# Altium schematic sheet Power.SchDoc
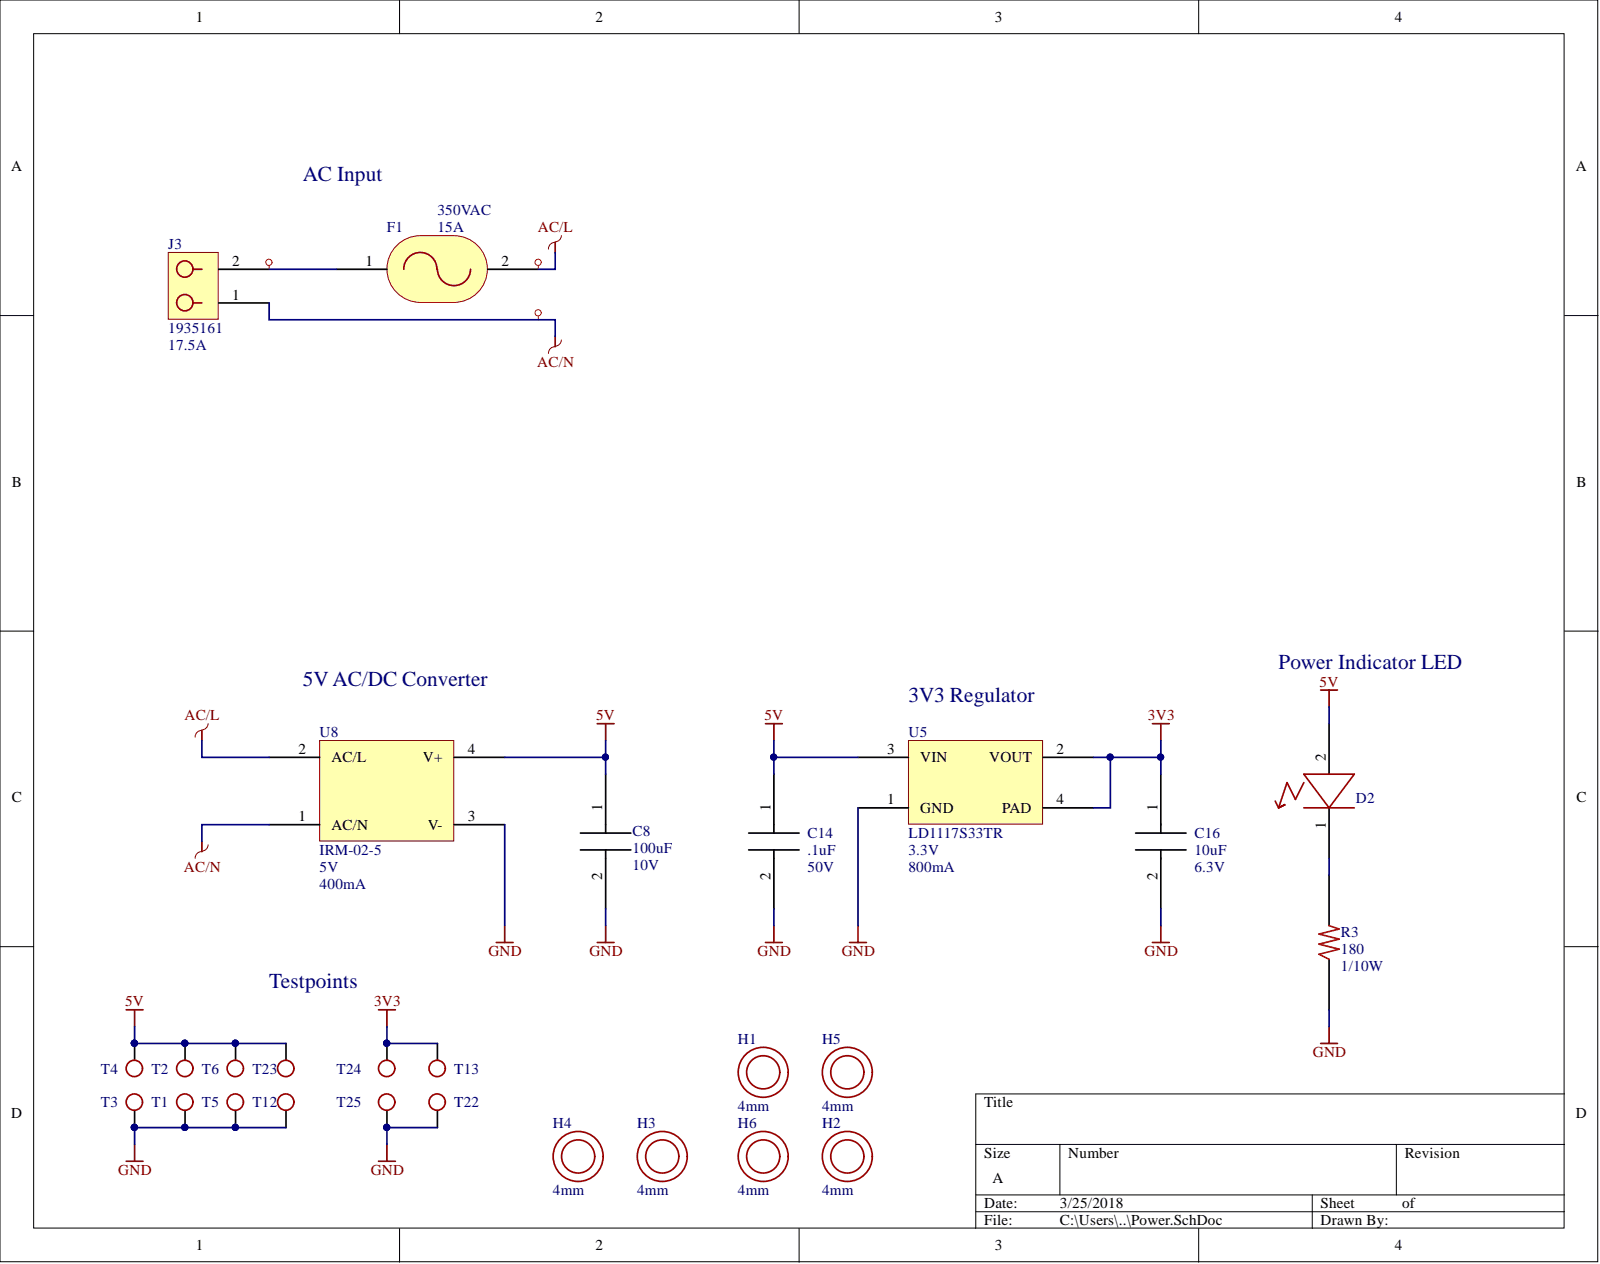
[diagram p0: Power.SchDoc]

## Parts
C8  value 100uF  Rating: 10V  [1210]  (hidden comment: C_CAP_1210)
C14  value .1uF  Rating: 50V  [0603]  (hidden comment: C_CAP_0603)
C16  value 10uF  Rating: 6.3V  [0603]  (hidden comment: C_CAP_0603)
D2  D_LED_LED5MM  [LED5MM]  (value not drawn)
F1  value 15A  Rating: 350VAC  [2410]  (hidden comment: F_FUSE_2410)
H1  value 4mm  [MOUNTHOLE4MM]  (hidden comment: H_MOUNTHOLE_MOUNTHOLE4MM)
H2  value 4mm  [MOUNTHOLE4MM]  (hidden comment: H_MOUNTHOLE_MOUNTHOLE4MM)
H3  value 4mm  [MOUNTHOLE4MM]  (hidden comment: H_MOUNTHOLE_MOUNTHOLE4MM)
H4  value 4mm  [MOUNTHOLE4MM]  (hidden comment: H_MOUNTHOLE_MOUNTHOLE4MM)
H5  value 4mm  [MOUNTHOLE4MM]  (hidden comment: H_MOUNTHOLE_MOUNTHOLE4MM)
H6  value 4mm  [MOUNTHOLE4MM]  (hidden comment: H_MOUNTHOLE_MOUNTHOLE4MM)
J3  Rating: 17.5A  [1935161]  (hidden comment: J_2POSTERM_1935161)
R3  value 180  Rating: 1/10W  [0603]  (hidden comment: R_RES_0603)
T1  TESTPOINT  [TESTPOINT1MM]  (value not drawn)
T2  TESTPOINT  [TESTPOINT1MM]  (value not drawn)
T3  TESTPOINT  [TESTPOINT1MM]  (value not drawn)
T4  TESTPOINT  [TESTPOINT1MM]  (value not drawn)
T5  TESTPOINT  [TESTPOINT1MM]  (value not drawn)
T6  TESTPOINT  [TESTPOINT1MM]  (value not drawn)
T12  TESTPOINT  [TESTPOINT1MM]  (value not drawn)
T13  TESTPOINT  [TESTPOINT1MM]  (value not drawn)
T22  TESTPOINT  [TESTPOINT1MM]  (value not drawn)
T23  TESTPOINT  [TESTPOINT1MM]  (value not drawn)
T24  TESTPOINT  [TESTPOINT1MM]  (value not drawn)
T25  TESTPOINT  [TESTPOINT1MM]  (value not drawn)
U5  value 3.3V  PartNumber: LD1117S33TR  [SOT223]  (hidden comment: U_LD1117S33TR_SOT223)
U8  value 5V  [IRM-02-5]  (hidden comment: U_IRM-02-5_IRM-02-5)

## Nets
3V3: C16.1 T13.1 T24.1 U5.2(VOUT) U5.4(PAD)
5V: C8.1 C14.1 D2.2 T2.1 T4.1 T6.1 T23.1 U5.3(VIN) U8.4(V+)
AC/L: F1.2 U8.2(AC/L)
AC/N: J3.1 U8.1(AC/N)
GND: C8.2 C14.2 C16.2 R3.1 T1.1 T3.1 T5.1 T12.1 T22.1 T25.1 U5.1(GND) U8.3(V-)
NetD2_1: D2.1 R3.2
NetF1_1: F1.1 J3.2

## Library data of the parts
C8 = C_CAP_1210: Manufacturer: Murata; PartNumber: GRM32ER61A107ME20L; Component Kind: Standard; Library Name: SeniorProject.SchLib
C14 = C_CAP_0603: Manufacturer: KEMET; PartNumber: C0603C104K5RACAUTO; Component Kind: Standard; Library Name: SeniorProject.SchLib
C16 = C_CAP_0603: Manufacturer: Taiyo Yuden; PartNumber: JMK107ABJ106MA-T; Component Kind: Standard; Library Name: SeniorProject.SchLib
F1 = F_FUSE_2410: Manufacturer: Bel Fuse; PartNumber: 0679H9150-05; Component Kind: Standard; Library Name: SeniorProject.SchLib
J3 = J_2POSTERM_1935161: Manufacturer: Phoenix Contact; Component Kind: Standard; PartNumber: 1935161; Library Name: SeniorProject.SchLib
R3 = R_RES_0603: Manufacturer: Yageo; PartNumber: RC0603JR-07180RL; Component Kind: Standard; Library Name: SeniorProject.SchLib
T1-T6 T12 T13 T22-T25 = T_TESTPOINT_TESTPOINT1MM: Value: 1mm; Component Kind: Standard; Library Name: SeniorProject.SchLib
U5 = U_LD1117S33TR_SOT223: Manufacturer: STM; Rating: 800mA; Component Kind: Standard; Library Name: SeniorProject.SchLib
U8 = U_IRM-02-5_IRM-02-5: Rating: 400mA; Component Kind: Standard; PartNumber: IRM-02-5; Library Name: SeniorProject.SchLib
D2 = D_LED_LED5MM: Component Kind: Standard; Library Name: SeniorProject.SchLib
H1-H6 = H_MOUNTHOLE_MOUNTHOLE4MM: Component Kind: Standard; Library Name: SeniorProject.SchLib
